# Altium schematic sheet Tracker_v1_1.SchDoc(Tracker_v1_1) (continued)

## Library data of the parts
C1 C4 C8 C13 C14 C17 C20 C23 = C0603C106M8PACTU: CAP CER 10UF 10V X5R 0603; Manufacturer: KEMET; Supplier Part Number 1: 2820985RL; Supplier 1: Farnell; Stock 1: 0; Pricing 1: 150=0.424, 500=0.311, 1000=0.26 (EUR); Manufacturer Part Number: C0603C106M8PACTU; Library Name: Tracker_v1_1_sch.SchLib; Component Kind: Standard
C2 C3 C7 C9-C11 C18 C19 C21 C22 = C0603C104J3RACTU: CAP CER 0.1UF 25V X7R 0603; Manufacturer: KEMET; Tolerance: 5%; Dielectric Material: Ceramic; Capacitance: 100nF; Width: 0.031inch; Voltage Rating (DC): 25V; Voltage Rating: 25V; Voltage: 25V; Thickness: 0.034inch; Termination: SMD/SMT; Supplier Part Number 1: 1650833RL; Supplier 1: Farnell; Stock 1: 0; REACH SVHC: No SVHC; Radiation Hardening: No; Pricing 1: 150=0.158, 500=0.132, 1000=0.12 (EUR); Packaging: Tape and Reel; Package Quantity: 4000; Number of Pins: 2; Mount: Surface Mount,PCB; Min Operating Temperature: -55°C; Max Operating Temperature: 125°C; Manufacturer Part Number: C0603C104J3RACTU; Library Name: Tracker_v1_1_sch.SchLib; Length: 1.6mm; Lead Pitch: 0.7mm; Height: 0.8mm; Dielectric: X7R; Depth: 0.8mm; Contact Plating: Tin,Matte; ComponentLink4URL: http://www.kemet.com/kemet/web/homepage/kechome.nsf/vapubfiles/KEM_C1002_X7R_SMD.pdf/$file/KEM_C1002_X7R_SMD.pdf?src-supplier=Element14; ComponentLink4Description: http://www.kemet.com/kemet/web/homepage/kechome.nsf/vapubfiles/KEM_C1002_X7R_SMD.pdf/$file/KEM_C1002_X7R_SMD.pdf?src-supplier=Element14; ComponentLink3URL: https://www.alliedelec.com/m/d/9bfe7773041ffe9d406e68bc7b377fdd.pdf?src-supplier=Allied+Electronics; ComponentLink3Description: https://www.alliedelec.com/m/d/9bfe7773041ffe9d406e68bc7b377fdd.pdf?src-supplier=Allied+Electronics; ComponentLink2URL: http://www.farnell.com/datasheets/1851736.pdf?src-supplier=Element14; ComponentLink2Description: http://www.farnell.com/datasheets/1851736.pdf?src-supplier=Element14; ComponentLink1URL: https://www.verical.com/server-webapp/html/getDatasheet?partID=33575&src-supplier=Verical; ComponentLink1Description: https://www.verical.com/server-webapp/html/getDatasheet?partID=33575&src-supplier=Verical; Component Kind: Standard; Case Code (Metric): 1608; Case Code (Imperial): 0603; Case/Package: 0603
C5 C15 = C0603C105K8RACTU: Cap Ceramic 1uF 10V X7R 10% SMD 0603 125C T/R; Manufacturer: KEMET; Dissipation Factor: 5%; Width: 0.8mm; Voltage Rating (DC): 10V; Voltage Rating: 10V; Tolerance: 10%; Thickness: 0.035inch; Termination: SMD/SMT; Supplier Part Number 1: 2522396RL; Supplier 1: Farnell; Stock 1: 0; REACH SVHC: No SVHC; Radiation Hardening: No; Pricing 1: 150=0.0567, 500=0.0425, 1000=0.0372 (EUR); Packaging: Tape and Reel; Package Quantity: 1; Mount: Surface Mount; Min Operating Temperature: -55°C; Max Operating Temperature: 125°C; Manufacturer Part Number: C0603C105K8RACTU; Library Name: Tracker_v1_1_sch.SchLib; Length: 1.6mm; Height: 0.8mm; Dielectric Material: Ceramic; Dielectric: X7R; Depth: 0.8mm; Contact Plating: Tin,Matte; ComponentLink1URL: https://www.verical.com/server-webapp/html/getDatasheet?partID=212378&src-supplier=Verical; ComponentLink1Description: https://www.verical.com/server-webapp/html/getDatasheet?partID=212378&src-supplier=Verical; Component Kind: Standard; Case Code (Metric): 1608; Case Code (Imperial): 0603; Case/Package: 0603; Capacitance: 1uF
C6 = C0603C101K5GACTU: Cap Ceramic 100pF 50V C0G 10% SMD 0603 125C Paper T/R; Manufacturer: KEMET; Dissipation Factor: 0.1%; Width: 0.031inch; Voltage Rating (DC): 50V; Voltage Rating: 50V; Tolerance: 10%; Thickness: 0.034inch; Termination: SMD/SMT; Supplier Part Number 1: 1823375; Supplier 1: Farnell; Stock 1: 0; REACH SVHC: No SVHC; Radiation Hardening: No; Packaging: Tape and Reel; Package Quantity: 1; Mount: Surface Mount,PCB; Min Operating Temperature: -55°C; Max Operating Temperature: 125°C; Manufacturer Part Number: C0603C101K5GACTU; Library Name: Tracker_v1_1_sch.SchLib; Length: 1.6mm; Lead Pitch: 0.7mm; Lead Free: Lead Free; Height: 0.8mm; Dielectric Material: Ceramic; Dielectric: C0G; Depth: 0.8mm; Contact Plating: Tin,Matte; ComponentLink4URL: http://www.mouser.com/ds/2/212/KEM_C1003_C0G_SMD-1101588.pdf?src-supplier=Mouser; ComponentLink4Description: http://www.mouser.com/ds/2/212/KEM_C1003_C0G_SMD-1101588.pdf?src-supplier=Mouser; ComponentLink3URL: http://www.farnell.com/datasheets/1828801.pdf?src-supplier=Element14; ComponentLink3Description: http://www.farnell.com/datasheets/1828801.pdf?src-supplier=Element14; ComponentLink2URL: https://www.verical.com/server-webapp/html/getDatasheet?partID=77366&src-supplier=Verical; ComponentLink2Description: https://www.verical.com/server-webapp/html/getDatasheet?partID=77366&src-supplier=Verical; ComponentLink1URL: http://www.onlinecomponents.com/productFiles/mf-KEM/kem_c1003_c0g_smd.pdf?src-supplier=Onlinecomponents.com; ComponentLink1Description: http://www.onlinecomponents.com/productFiles/mf-KEM/kem_c1003_c0g_smd.pdf?src-supplier=Onlinecomponents.com; Component Kind: Standard; Case Code (Metric): 1608; Case Code (Imperial): 0603; Case/Package: 0603; Capacitance: 100pF
C12 = C0603C475K9PACTU: CAP CER 4.7UF 6.3V X5R 0603; Manufacturer: KEMET; Tolerance: 10%; Dissipation Factor: 5%; Dielectric Material: Ceramic; Width: 0.031inch; Voltage Rating (DC): 6.3V; Voltage Rating: 6.3V; Voltage: 6.3V; Thickness: 0.035inch; Termination: SMD/SMT; Supplier Part Number 1: 1310155; Supplier 1: Farnell; Stock 1: 0; REACH SVHC: No SVHC; Radiation Hardening: No; Packaging: Tape and Reel; Package Quantity: 1; Number of Pins: 2; Mount: Surface Mount,PCB; Min Operating Temperature: -55°C; Max Operating Temperature: 85°C; Manufacturer Part Number: C0603C475K9PACTU; Library Name: Tracker_v1_1_sch.SchLib; Length: 1.6mm; Lead Pitch: 0.7mm; Lead Free: Lead Free; Height: 0.8mm; Dielectric: X5R; Depth: 0.8mm; Contact Plating: Tin,Matte; ComponentLink4URL: http://www.farnell.com/datasheets/40889.pdf?src-supplier=Element14; ComponentLink4Description: http://www.farnell.com/datasheets/40889.pdf?src-supplier=Element14; ComponentLink3URL: http://www.farnell.com/datasheets/1789732.pdf?src-supplier=Element14; ComponentLink3Description: http://www.farnell.com/datasheets/1789732.pdf?src-supplier=Element14; ComponentLink2URL: http://www.farnell.com/datasheets/1732700.pdf?src-supplier=Element14; ComponentLink2Description: http://www.farnell.com/datasheets/1732700.pdf?src-supplier=Element14; ComponentLink1URL: https://api.kemet.com/component-edge/download/datasheet/C0603C475K9PACTU.pdf?src-supplier=Digi-Key; ComponentLink1Description: https://api.kemet.com/component-edge/download/datasheet/C0603C475K9PACTU.pdf?src-supplier=Digi-Key; Component Kind: Standard; Case Code (Metric): 1608; Case Code (Imperial): 0603; Case/Package: 0603; Capacitance: 4.7uF
C16 = C0603C103J3GACTU: 0603 C 10nF Ceramic Multilayer Capacitor, 25 V, +125degC, C0G Dielectric, +/-5%; Manufacturer: KEMET; Voltage Rating (DC): 25V; Voltage: 50V; Tolerance: 5%; Temperature Coefficient: 30ppm/°C; Dissipation Factor: 0.1%; Width: 0.8mm; Voltage Rating: 25V; Thickness: 0.034inch; Termination: SMD/SMT; Supplier Part Number 1: C0603C103J3GACTU-ND; Supplier 1: Digi-Key; Stock 1: 0; REACH SVHC: No SVHC; Radiation Hardening: No; Packaging: Tape and Reel; Package Quantity: 4000; Number of Pins: 2; Mount: Surface Mount; Min Operating Temperature: -55°C; Max Operating Temperature: 125°C; Manufacturer Part Number: C0603C103J3GACTU; Library Name: Tracker_v1_1_sch.SchLib; Length: 1.6mm; Lead Free: Lead Free; Height: 0.8mm; Dielectric Material: Ceramic; Dielectric: C0G; Depth: 0.8mm; Contact Plating: Tin,Matte; ComponentLink4URL: http://www.mouser.com/ds/2/212/KEM_C1003_C0G_SMD-1101588.pdf?src-supplier=Mouser; ComponentLink4Description: http://www.mouser.com/ds/2/212/KEM_C1003_C0G_SMD-1101588.pdf?src-supplier=Mouser; ComponentLink3URL: http://www.farnell.com/datasheets/1732695.pdf?src-supplier=Element14; ComponentLink3Description: http://www.farnell.com/datasheets/1732695.pdf?src-supplier=Element14; ComponentLink2URL: http://www.farnell.com/datasheets/2315092.pdf?src-supplier=Farnell; ComponentLink2Description: http://www.farnell.com/datasheets/2315092.pdf?src-supplier=Farnell; ComponentLink1URL: https://www.verical.com/server-webapp/html/getDatasheet?partID=556319&src-supplier=Verical; ComponentLink1Description: https://www.verical.com/server-webapp/html/getDatasheet?partID=556319&src-supplier=Verical; Component Kind: Standard; Case Code (Metric): 1608; Case Code (Imperial): 0603; Case/Package: 0603; Capacitance: 10nF
CON1 = Micro USB SMD: AMPHENOL FCI - 10104110-0001LF - MICRO USB, 2.0 TYPE B, RECEPTACLE, SMT; USB Standard: USB 2.0; USB Connector Type: Micro USB Type B; SVHC: No SVHC (15-Jun-2015); No. of Positions: 5; No. of Contacts: 5Contacts<!--FANDB--><div class="features-and-benefits-container"><div class="features-and-benefits-description"><span class="features-benefits-value">The 10104110-0001LF is a micro-USB type-B Receptacle Connector, comply with physical, electrical and environmental requirements of micro-USB standard (USB 2.0). Space receptacles and plugs are available in a wide variety of mounting and termination configurations, permitting their use in various applications. Compared with the standard mini-B connectors, the size is reduced by approximately 60% while still allowing a high-speed data transfer of 480; Contact Termination Type: Surface Mount; Contact Termination: Surface Mount Right Angle; Connector Type: USB Micro B; Supplier Part Number 1: 2293753; Supplier 1: Farnell; Stock 1: 25585; RoHS: YES; Product Range: -; Pricing 1: 1=0.464, 10=0.451, 25=0.437, 50=0.424, 100=0.41, 250=0.291 (GBP); Orientation: Right Angle; Manufacturer Part Number: 10104110-0001LF; Manufacturer: AMPHENOL FCI; Library Name: Tracker_v1_1_sch.SchLib; Gender: Receptacle; Contact Plating: Gold Plated Contacts; Contact Material: Copper Alloy; Connector Mounting: Surface Mount; ComponentLink1URL: http://www.farnell.com/datasheets/1469072.pdf; ComponentLink1Description: http://www.farnell.com/datasheets/1469072.pdf; Component Kind: Standard
CON2 CON3 = 73412-0110: RF Connectors / Coaxial Connectors MCX V PCB JACK SMT 1.25MM MNT HGT; Manufacturer: Molex; Voltage Rating (AC): 60V; Polarity: Standard; Max Frequency: 6GHz; Mating Cycles: 30; Insulation Resistance: 500MR; Impedance: 50R; Gender: Female; Body Plating: Gold; Body Material: Liquid Crystal Polymer,Polymer; Voltage Rating: 60V; Termination: Solder; Supplier Part Number 1: 1340200; Supplier 1: Farnell; Stock 1: 4654; REACH SVHC: Unknown; Radiation Hardening: No; Pricing 1: 1=0.402, 150=0.368, 400=0.334, 1250=0.327, 2500=0.32 (EUR); Packaging: Tape and Reel; Package Quantity: 5000; Orientation: Straight; Mount: PCB,Surface Mount,Through Hole; Min Operating Temperature: -40°C; Max Operating Temperature: 90°C; Material: Bronze; Manufacturer Part Number: 73412-0110; Library Name: Tracker_v1_1_sch.SchLib; Lead Free: Lead Free; Halogen Free: Low Halogen; Frequency: 6GHz; Contact Plating: Gold; Contact Material: Brass,Bronze; Connector Type: Coaxial; ComponentLink7URL: http://www.molex.com/webdocs/datasheets/pdf/en-us/0734120110_RF_COAX_CONNECTORS.pdf?src-supplier=Avnet; ComponentLink7Description: http://www.molex.com/webdocs/datasheets/pdf/en-us/0734120110_RF_COAX_CONNECTORS.pdf?src-supplier=Avnet; ComponentLink6URL: http://www.farnell.com/datasheets/1808577.pdf?src-supplier=Element14; ComponentLink6Description: http://www.farnell.com/datasheets/1808577.pdf?src-supplier=Element14; ComponentLink5URL: http://www.onlinecomponents.com/productFiles/mf-MOL/1387750734120110_rf_coax_nectors.pdf?src-supplier=Onlinecomponents.com; ComponentLink5Description: http://www.onlinecomponents.com/productFiles/mf-MOL/1387750734120110_rf_coax_nectors.pdf?src-supplier=Onlinecomponents.com; ComponentLink4URL: http://www.molex.com/webdocs/datasheets/pdf/en-us/0734120110_RF_COAX_CONNECTORS.pdf?src-supplier=Mouser; ComponentLink4Description: http://www.molex.com/webdocs/datasheets/pdf/en-us/0734120110_RF_COAX_CONNECTORS.pdf?src-supplier=Mouser; ComponentLink3URL: http://www.farnell.com/datasheets/1841262.pdf?src-supplier=Farnell; ComponentLink3Description: http://www.farnell.com/datasheets/1841262.pdf?src-supplier=Farnell; ComponentLink2URL: http://uk.rs-online.com/webdocs/13c4/0900766b813c49d5.pdf?src-supplier=RSComponents; ComponentLink2Description: http://uk.rs-online.com/webdocs/13c4/0900766b813c49d5.pdf?src-supplier=RSComponents; ComponentLink1URL: http://www.molex.com/webdocs/datasheets/pdf/en-us/0734120110_RF_COAX_CONNECTORS.pdf?src-supplier=Avnet+Europe; ComponentLink1Description: http://www.molex.com/webdocs/datasheets/pdf/en-us/0734120110_RF_COAX_CONNECTORS.pdf?src-supplier=Avnet+Europe; Component Kind: Standard
D1 = MBR120VLSFT1G: DIODE SCHOTTKY 20V 1A SOD123FL; Manufacturer: ON Semiconductor; Polarity: Standard; Peak Reverse Current: 600uA; Peak Non-Repetitive Surge Current: 45A; Forward Voltage: 340mV; Element Configuration: Single; Width: 1.8mm; Voltage Rating (DC): 20V; Supplier Part Number 1: 2393584; Supplier 1: Farnell; Stock 1: 0; REACH SVHC: No SVHC; Radiation Hardening: No; Packaging: Tape and Reel; Package Quantity: 3000; Output Current: 1A; Number of Pins: 2; Min Operating Temperature: -65°C; Max Surge Current: 45A; Max Reverse Voltage (DC): 20V; Max Reverse Leakage Current: 600uA; Max Repetitive Reverse Voltage (Vrrm): 20V; Max Operating Temperature: 125°C; Max Forward Surge Current (Ifsm): 45A; Manufacturer Part Number: MBR120VLSFT1G; Library Name: Tracker_v1_1_sch.SchLib; Length: 2.9mm; Lead Free: Lead Free; Height: 0.9mm; Halogen Free: Halogen Free; Forward Current: 1A; Current Rating: 1A; Contact Plating: Tin,Matte; ComponentLink6URL: http://www.onsemi.cn/pub_link/Collateral/MBR120VLSFT1-D.PDF?src-supplier=Avnet+Asia; ComponentLink6Description: http://www.onsemi.cn/pub_link/Collateral/MBR120VLSFT1-D.PDF?src-supplier=Avnet+Asia; ComponentLink5URL: http://www.onsemi.com/pub/Collateral/MBR120VLSFT1-D.PDF?src-supplier=Digi-Key; ComponentLink5Description: http://www.onsemi.com/pub/Collateral/MBR120VLSFT1-D.PDF?src-supplier=Digi-Key; ComponentLink4URL: http://www.onsemi.com/pub/Collateral/MBR120VLSFT1-D.PDF?src-supplier=Future+Electronics; ComponentLink4Description: http://www.onsemi.com/pub/Collateral/MBR120VLSFT1-D.PDF?src-supplier=Future+Electronics; ComponentLink3URL: https://www.alliedelec.com/m/d/71572cff3445748bb7aa75769c4030bb.pdf?src-supplier=Allied+Electronics; ComponentLink3Description: https://www.alliedelec.com/m/d/71572cff3445748bb7aa75769c4030bb.pdf?src-supplier=Allied+Electronics; ComponentLink2URL: http://www.onsemi.cn/pub_link/Collateral/MBR120VLSFT1-D.PDF?src-supplier=Avnet+Europe; ComponentLink2Description: http://www.onsemi.cn/pub_link/Collateral/MBR120VLSFT1-D.PDF?src-supplier=Avnet+Europe; ComponentLink1URL: http://www.farnell.com/datasheets/85900.pdf?src-supplier=Element14; ComponentLink1Description: http://www.farnell.com/datasheets/85900.pdf?src-supplier=Element14; Component Kind: Standard; Average Rectified Current: 1A
F1 F2 = 046701.5NR: FUSE BOARD MNT 1.5A 32VAC 65VDC; Manufacturer: Littelfuse; Working Voltage: 32V; Resistance: 41mR; Power Dissipation: 121.7mW; Fuse Type: Board Mount (Cartridge Style Excluded); DC Cold Resistance: 38.5mR; Body Material: Thermoplastic; Approvals: UL,CSA; Width: 0.813mm; Voltage Rating (DC): 32V; Voltage Rating (AC): 32V; Voltage Rating: 32V; Termination: SMD/SMT; Supplier Part Number 1: 1596948RL; Supplier 1: Farnell; Stock 1: 0; REACH SVHC: Unknown; Radiation Hardening: No; Packaging: Tape and Reel; Package Quantity: 5000; Number of Pins: 2; Mount: Surface Mount; Min Operating Temperature: -55°C; Max Operating Temperature: 90°C; Manufacturer Part Number: 046701.5NR; Library Name: Tracker_v1_1_sch.SchLib; Length: 1.6mm; Lead Free: Lead Free; Height: 0.012inch; Fuse Current: 1.5A; Depth: 0.81mm; Current Rating: 1.5A; Composition: Thin Film; ComponentLink4URL: https://www.verical.com/server-webapp/html/getDatasheet?partID=772543&src-supplier=Verical; ComponentLink4Description: https://www.verical.com/server-webapp/html/getDatasheet?partID=772543&src-supplier=Verical; ComponentLink3URL: http://www.littelfuse.com/data/en/Data_Sheets/467.pdf?src-supplier=Future+Electronics; ComponentLink3Description: http://www.littelfuse.com/data/en/Data_Sheets/467.pdf?src-supplier=Future+Electronics; ComponentLink2URL: http://www.littelfuse.com/~/media/electronics/datasheets/fuses/littelfuse_fuse_467_datasheet.pdf.pdf?src-supplier=Digi-Key; ComponentLink2Description: http://www.littelfuse.com/~/media/electronics/datasheets/fuses/littelfuse_fuse_467_datasheet.pdf.pdf?src-supplier=Digi-Key; ComponentLink1URL: http://www.farnell.com/datasheets/1851810.pdf?src-supplier=Element14; ComponentLink1Description: http://www.farnell.com/datasheets/1851810.pdf?src-supplier=Element14; Component Kind: Standard; Case Code (Metric): 1608; Case Code (Imperial): 0603; Case/Package: 0603
J1 = Header 2x1: Library Name: Tracker_v1_1_sch.SchLib; Component Kind: Standard
L1 = L1210R2R2MDWIT: FIXED IND 2.2UH 2A 80 MOHM SMD; Manufacturer: KEMET; Tolerance: 20%; Test Frequency: 100kHz; Shielding: Unshielded; Self Resonant Frequency: 190MHz; DC Resistance (DCR): 80mR; DC Current: 1.13A; Core Material: Ferrite; Width: 0.098inch; Termination: SMD/SMT; Supplier Part Number 1: 399-9598-6-ND; Supplier 1: Digi-Key; Stock 1: 17546; REACH SVHC: No SVHC; Radiation Hardening: No; Pricing 1: 1=0.55, 10=0.479, 100=0.3421, 500=0.2874 (USD); Packaging: Tape and Reel; Package Quantity: 1000; Mount: Surface Mount; Min Operating Temperature: -40°C; Military Standard: Not; Max Operating Temperature: 105°C; Max DC Current: 2A; Manufacturer Part Number: L1210R2R2MDWIT; Library Name: Tracker_v1_1_sch.SchLib; Length: 0.126inch; Inductance Tolerance: 20%; Inductance: 2.2uH; Height - Seated (Max): 0.106inch; Height: 2.5mm; Depth: 2.7mm; Current Rating: 2A; Composition: Ceramic,Wirewound; ComponentLink5URL: http://www.kemet.com/Lists/ProductCatalog/Attachments/57/F3115.pdf?src-supplier=Avnet; ComponentLink5Description: http://www.kemet.com/Lists/ProductCatalog/Attachments/57/F3115.pdf?src-supplier=Avnet; ComponentLink4URL: https://api.kemet.com/component-edge/download/datasheet/L1210R2R2MDWIT.pdf?src-supplier=Digi-Key; ComponentLink4Description: https://api.kemet.com/component-edge/download/datasheet/L1210R2R2MDWIT.pdf?src-supplier=Digi-Key; ComponentLink3URL: http://www.farnell.com/datasheets/27262.pdf?src-supplier=Element14; ComponentLink3Description: http://www.farnell.com/datasheets/27262.pdf?src-supplier=Element14; ComponentLink2URL: https://content.kemet.com/datasheets/F3115.pdf?src-supplier=Avnet+Europe; ComponentLink2Description: https://content.kemet.com/datasheets/F3115.pdf?src-supplier=Avnet+Europe; ComponentLink1URL: https://content.kemet.com/datasheets/F3115.pdf?src-supplier=Mouser; ComponentLink1Description: https://content.kemet.com/datasheets/F3115.pdf?src-supplier=Mouser; Component Kind: Standard; Case Code (Metric): 3225; Case Code (Imperial): 1210; Case/Package: 1210
L2 = LQG18HN27NJ00D: Inductor RF Chip Multi-Layer 27nH 5% 100MHz 12Q-Factor Air 300mA 550mOhm DCR 0603 T/R; Manufacturer: Murata; Shielding: Unshielded; Self Resonant Frequency: 2GHz; Q Factor: 12; DC Resistance (DCR): 550mR; Width: 0.8mm; Tolerance: 5%; Test Frequency: 100MHz; Termination: SMD/SMT; Supplier Part Number 1: 490-6579-2-ND; Supplier 1: Digi-Key; Stock 1: 0; REACH SVHC: No SVHC; Radiation Hardening: No; Packaging: Tape and Reel; Package Quantity: 4000; Mount: Surface Mount; Min Operating Temperature: -55°C; Military Standard: Not; Max Operating Temperature: 85°C; Max DC Current: 300mA; Manufacturer Part Number: LQG18HN27NJ00D; Library Name: Tracker_v1_1_sch.SchLib; Length: 0.063inch; Lead Free: Lead Free; Inductance Tolerance: 5%; Inductance: 27nH; Height - Seated (Max): 0.037inch; Height: 0.8mm; Depth: 0.95mm; DC Current: 300mA; Current Rating: 300mA; Core Material: Air; ComponentLink6URL: http://uk.rs-online.com/webdocs/0f10/0900766b80f1087c.pdf?src-supplier=RSComponents; ComponentLink6Description: http://uk.rs-online.com/webdocs/0f10/0900766b80f1087c.pdf?src-supplier=RSComponents; ComponentLink5URL: http://search.murata.co.jp/Ceramy/image/img/PDF/ENG/L0075S0083LQG18H.pdf?src-supplier=Avnet+Europe; ComponentLink5Description: http://search.murata.co.jp/Ceramy/image/img/PDF/ENG/L0075S0083LQG18H.pdf?src-supplier=Avnet+Europe; ComponentLink4URL: http://search.murata.co.jp/Ceramy/image/img/PDF/ENG/L0075S0083LQG18H.pdf?src-supplier=Avnet; ComponentLink4Description: http://search.murata.co.jp/Ceramy/image/img/PDF/ENG/L0075S0083LQG18H.pdf?src-supplier=Avnet; ComponentLink3URL: http://psearch.en.murata.com/inductor/product/LQG18HN27NJ00_.pdf?src-supplier=Digi-Key; ComponentLink3Description: http://psearch.en.murata.com/inductor/product/LQG18HN27NJ00_.pdf?src-supplier=Digi-Key; ComponentLink2URL: http://www.farnell.com/datasheets/5102.pdf?src-supplier=Element14; ComponentLink2Description: http://www.farnell.com/datasheets/5102.pdf?src-supplier=Element14; ComponentLink1URL: http://search.murata.co.jp/Ceramy/image/img/P02/JELF243B-0002.pdf?src-supplier=Digi-Key; ComponentLink1Description: http://search.murata.co.jp/Ceramy/image/img/P02/JELF243B-0002.pdf?src-supplier=Digi-Key; Component Kind: Standard; Case Code (Metric): 1608; Case Code (Imperial): 0603; Case/Package: 0603
LED1-LED3 = KP-1608CGCK: KINGBRIGHT - KP-1608CGCK - LED, 0603, 50MCD, GREEN; Wavelength: 570nm; Viewing Angle: 120°; Number of LEDs: 1; Lens Style: Rectangular,Diffused,Colorless; Lens Color: Clear; Forward Voltage: 2.1V; Forward Current: 20mA; Width: 1.6mm; Supplier Part Number 1: 2290328; Supplier 1: Farnell; Stock 1: 18535; REACH SVHC: No SVHC; Radiation Hardening: No; Pricing 1: 5=0.157, 50=0.143, 100=0.0934, 500=0.0789, 1000=0.0621 (EUR); Packaging: Tape and Reel; Number of Pins: 2; Mount: Surface Mount; Manufacturer Part Number: KP-1608CGCK; Manufacturer: Kingbright; Luminous Intensity: 50mcd; Library Name: Tracker_v1_1_sch.SchLib; Length: 0.8mm; Height: 1.1mm; Dominant Wavelength: 570nm; ComponentLink2URL: http://www.rapidonline.com/pdf/72-8670.pdf?src-supplier=Rapid+Electronics; ComponentLink2Description: http://www.rapidonline.com/pdf/72-8670.pdf?src-supplier=Rapid+Electronics; ComponentLink1URL: http://www.farnell.com/datasheets/2045956.pdf?src-supplier=Element14; ComponentLink1Description: http://www.farnell.com/datasheets/2045956.pdf?src-supplier=Element14; Component Kind: Standard; Color: Green; Case/Package: 1608
MH1-MH4 = MH_7.4MM_NC: Library Name: Tracker_v1_1_sch.SchLib; Component Kind: Standard
R1 R4 R9 = CR0603-JW-103EAS: RES 10K OHM 5% 0.01W 0603; Manufacturer: Bourns; Supplier Part Number 1: 2752989RL; Supplier 1: Farnell; Stock 1: 0; Pricing 1: 150=0.0181, 500=0.0155, 1000=0.007 (EUR); Manufacturer Part Number: CR0603-JW-103EAS; Library Name: Tracker_v1_1_sch.SchLib; Component Kind: Standard
R2 R3 = CRCW060327R0FKEA: RES SMD 27 OHM 1% 1/10W 0603; Manufacturer: Vishay; Voltage Rating (DC): 75V; Temperature Coefficient: 100ppm/°C; Power Rating: 100mW; Width: 0.85mm; Weight: 7.1E-05oz; Voltage Rating: 75V; Tolerance: 1%; Termination: SMD/SMT; Supplier Part Number 1: 1151876; Supplier 1: Farnell; Stock 1: 0; Resistance: 27R; REACH SVHC: Unknown; Radiation Hardening: No; Packaging: Tape and Reel; Package Quantity: 5000; Number of Pins: 2; Mount: Surface Mount; Min Operating Temperature: -55°C; Military Standard: Not; Max Operating Temperature: 155°C; Manufacturer Part Number: CRCW060327R0FKEA; Library Name: Tracker_v1_1_sch.SchLib; Length: 1.55mm; Lead Free: Lead Free; Height: 0.45mm; Depth: 0.85mm; Contact Plating: Tin; Composition: Thick Film; ComponentLink8URL: http://www.vishay.com/docs/20035/dcrcwe3.pdf?src-supplier=Future+Electronics; ComponentLink8Description: http://www.vishay.com/docs/20035/dcrcwe3.pdf?src-supplier=Future+Electronics; ComponentLink7URL: http://www.alliedelec.com/m/d/0c934d6939ccefa68dbe0b96d821c8cf.pdf?src-supplier=Allied+Electronics; ComponentLink7Description: http://www.alliedelec.com/m/d/0c934d6939ccefa68dbe0b96d821c8cf.pdf?src-supplier=Allied+Electronics; ComponentLink6URL: http://www.vishay.com/docs/20035/dcrcwe3.pdf?src-supplier=Avnet+Europe; ComponentLink6Description: http://www.vishay.com/docs/20035/dcrcwe3.pdf?src-supplier=Avnet+Europe; ComponentLink5URL: http://uk.rs-online.com/webdocs/0d98/0900766b80d98afc.pdf?src-supplier=RSComponents; ComponentLink5Description: http://uk.rs-online.com/webdocs/0d98/0900766b80d98afc.pdf?src-supplier=RSComponents; ComponentLink4URL: http://www.farnell.com/datasheets/2111203.pdf?src-supplier=Element14; ComponentLink4Description: http://www.farnell.com/datasheets/2111203.pdf?src-supplier=Element14; ComponentLink3URL: http://www.farnell.com/datasheets/1697337.pdf?src-supplier=Element14; ComponentLink3Description: http://www.farnell.com/datasheets/1697337.pdf?src-supplier=Element14; ComponentLink2URL: http://www.farnell.com/datasheets/2046716.pdf?src-supplier=Element14; ComponentLink2Description: http://www.farnell.com/datasheets/2046716.pdf?src-supplier=Element14; ComponentLink1URL: http://www.mouser.com/ds/2/427/dcrcwe3-109170.pdf?src-supplier=Mouser; ComponentLink1Description: http://www.mouser.com/ds/2/427/dcrcwe3-109170.pdf?src-supplier=Mouser; Component Kind: Standard; Case Code (Metric): 1608; Case Code (Imperial): 0603; Case/Package: 0603
R5 R6 = CR0603-JW-472ELF: Res Thick Film 0603 4.7K Ohm 5% 1/10W ±200ppm/°C Molded SMD SMD Paper Tape on Plastic Reel; Manufacturer: Bourns; Voltage Rating (DC): 50V; Resistance: 4.7kR; Power Rating: 100mW; Width: 0.8mm; Voltage Rating: 50V; Tolerance: 5%; Thickness: 457um; Termination: SMD/SMT; Temperature Coefficient: 200ppm/°C; Supplier Part Number 1: 2371774; Supplier 1: Farnell; Stock 1: 0; REACH SVHC: No SVHC; Radiation Hardening: No; Packaging: Tape and Reel; Package Quantity: 1; Number of Terminations: 2; Number of Pins: 2; Mount: Surface Mount; Min Operating Temperature: -55°C; Max Power Dissipation: 100mW; Max Operating Temperature: 155°C; Manufacturer Part Number: CR0603-JW-472ELF; Library Name: Tracker_v1_1_sch.SchLib; Length: 1.6mm; Height: 0.45mm; Features: Moisture Resistant; Depth: 0.8mm; Contact Plating: Tin,Lead; Composition: Thick Film; ComponentLink6URL: http://www.produktinfo.conrad.com/datenblaetter/1000000-1099999/001055503-da-01-en-SMD_WID_CR0603_JW_472ELF_4_7K_OHM_1_10W.pdf?src-supplier=Conrad; ComponentLink6Description: http://www.produktinfo.conrad.com/datenblaetter/1000000-1099999/001055503-da-01-en-SMD_WID_CR0603_JW_472ELF_4_7K_OHM_1_10W.pdf?src-supplier=Conrad; ComponentLink5URL: http://uk.rs-online.com/webdocs/0fcd/0900766b80fcdb72.pdf?src-supplier=RSComponents; ComponentLink5Description: http://uk.rs-online.com/webdocs/0fcd/0900766b80fcdb72.pdf?src-supplier=RSComponents; ComponentLink4URL: http://www.farnell.com/datasheets/1856384.pdf?src-supplier=Element14; ComponentLink4Description: http://www.farnell.com/datasheets/1856384.pdf?src-supplier=Element14; ComponentLink3URL: https://www.alliedelec.com/m/d/8318635a9e5af6f7dacb272be3e73d2e.pdf?src-supplier=Allied+Electronics; ComponentLink3Description: https://www.alliedelec.com/m/d/8318635a9e5af6f7dacb272be3e73d2e.pdf?src-supplier=Allied+Electronics; ComponentLink2URL: http://www.bourns.com/pdfs/chpreztr.pdf?src-supplier=Avnet; ComponentLink2Description: http://www.bourns.com/pdfs/chpreztr.pdf?src-supplier=Avnet; ComponentLink1URL: http://www.farnell.com/datasheets/2178762.pdf?src-supplier=Newark; ComponentLink1Description: http://www.farnell.com/datasheets/2178762.pdf?src-supplier=Newark; Component Kind: Standard; Case Code (Metric): 1608; Case Code (Imperial): 0603; Case/Package: 0603
R7 R10 R11 = CR0603-JW-511ELF: Res Thick Film 0603 510 Ohm 5% 1/10W ±200ppm/°C Molded SMD SMD Paper Tape on Plastic Reel; Manufacturer: Bourns; Voltage Rating: 50V; Power Rating: 100mW; Width: 0.8mm; Tolerance: 5%; Thickness: 457um; Termination: SMD/SMT; Temperature Coefficient: 200ppm/°C; Supplier Part Number 1: 2908378; Supplier 1: Farnell; Stock 1: 79590; Resistance: 510R; Radiation Hardening: No; Pricing 1: 10=0.016, 100=0.0113, 500=0.0089, 1000=0.0077 (EUR); Packaging: Tape and Reel; Package Quantity: 1; Number of Terminations: 2; Mount: Surface Mount; Min Operating Temperature: -55°C; Military Standard: Not; Max Power Dissipation: 100mW; Max Operating Temperature: 155°C; Manufacturer Part Number: CR0603-JW-511ELF; Library Name: Tracker_v1_1_sch.SchLib; Length: 1.6mm; Height: 0.022inch; Features: Moisture Resistant; Depth: 0.8mm; Contact Plating: Tin; Composition: Thick Film; ComponentLink3URL: https://www.bourns.com/docs/Product-Datasheets/CHPREZTR.pdf?src-supplier=Digi-Key; ComponentLink3Description: https://www.bourns.com/docs/Product-Datasheets/CHPREZTR.pdf?src-supplier=Digi-Key; ComponentLink2URL: http://www.mouser.com/ds/2/54/chpreztr-977002.pdf?src-supplier=Mouser; ComponentLink2Description: http://www.mouser.com/ds/2/54/chpreztr-977002.pdf?src-supplier=Mouser; ComponentLink1URL: http://www.bourns.com/pdfs/chpreztr.pdf?src-supplier=Avnet; ComponentLink1Description: http://www.bourns.com/pdfs/chpreztr.pdf?src-supplier=Avnet; Component Kind: Standard; Case Code (Metric): 1608; Case Code (Imperial): 0603; Case/Package: 0603
R8 R12 R14 R15 R17-R20 R22 = RMCF0603ZT0R00: RES 0 OHM JUMPER 1/10W 0603; Manufacturer: Stackpole Electronics; Working Voltage: 75V; Resistance: 0mR; Power Rating: 100mW; Current Rating: 1A; Width: 0.031inch; Supplier Part Number 1: RMCF0603ZT0R00-ND; Supplier 1: Digi-Key; Stock 1: 0; Radiation Hardening: No; Packaging: Tape and Reel; Number of Terminations: 2; Mount: Surface Mount; Min Operating Temperature: -55°C; Military Standard: Not; Max Power Dissipation: 100mW; Max Operating Temperature: 155°C; Manufacturer Part Number: RMCF0603ZT0R00; Library Name: Tracker_v1_1_sch.SchLib; Length: 1.55mm; Lead Free: Lead Free; Height: 0.022inch; Features: Automotive AEC-Q200; Depth: 0.8mm; Contact Plating: Tin,Matte; Composition: Thick Film; Component Kind: Standard; Case Code (Metric): 1608; Case Code (Imperial): 0603; Case/Package: 0603
R13 R21 = CPF-A-0603B100KE1: TE CONNECTIVITY         CPF-A-0603B100KE1             SMD Chip Resistor, Thin Film, 100 kohm, 50 V, 0603 [1608 Metric], 62.5 mW,  0.1%, CPF-A Series; Voltage Rating (DC): 50V; Temperature Coefficient: 25ppm/°C; Resistance: 100kR; Power Rating: 63mW; Number of Resistors: 1; Voltage Rating: 50V; Tolerance: 0.1%; Termination: Solder; Supplier Part Number 1: 2483881RL; Supplier 1: Farnell; Stock 1: 0; REACH SVHC: No SVHC; Pricing 1: 150=0.209, 250=0.198, 500=0.172, 1000=0.135, 5000=0.13 (EUR); Packaging: Tape and Reel; Number of Terminations: 2; Mount: Surface Mount; Manufacturer Part Number: CPF-A-0603B100KE1; Manufacturer: TE Connectivity; Library Name: Tracker_v1_1_sch.SchLib; ELV: Compliant; Composition: Thin Film; Component Kind: Standard; Case Code (Metric): 1608; Case Code (Imperial): 0603; Case/Package: 0603
R16 = CRCW060310R0FKEA: RES SMD 10 OHM 1% 1/10W 0603; Manufacturer: Vishay; Voltage Rating (DC): 75V; Temperature Coefficient: 100ppm/°C; Power Rating: 100mW; Width: 0.033inch; Weight: 7.1E-05oz; Voltage Rating: 75V; Tolerance: 1%; Termination: SMD/SMT; Supplier Part Number 1: 541-10.0HTR-ND; Supplier 1: Digi-Key; Stock 1: 0; Resistance: 10R; REACH SVHC: Unknown; Packaging: Tape and Reel; Package Quantity: 10; Number of Terminations: 2; Number of Pins: 2; Mount: Surface Mount; Min Operating Temperature: -55°C; Military Standard: Not; Max Power Dissipation: 100mW; Max Operating Temperature: 155°C; Manufacturer Part Number: CRCW060310R0FKEA; Library Name: Tracker_v1_1_sch.SchLib; Length: 1.55mm; Lead Free: Lead Free; Height: 0.45mm; Features: Automotive AEC-Q200; Depth: 0.85mm; Contact Plating: Tin; Composition: Thick Film; ComponentLink8URL: http://www.farnell.com/datasheets/2045673.pdf?src-supplier=Element14; ComponentLink8Description: http://www.farnell.com/datasheets/2045673.pdf?src-supplier=Element14; ComponentLink7URL: http://www.mouser.com/ds/2/427/dcrcwe3-109170.pdf?src-supplier=Mouser; ComponentLink7Description: http://www.mouser.com/ds/2/427/dcrcwe3-109170.pdf?src-supplier=Mouser; ComponentLink6URL: http://www.vishay.com/docs/20035/dcrcwe3.pdf?src-supplier=Avnet; ComponentLink6Description: http://www.vishay.com/docs/20035/dcrcwe3.pdf?src-supplier=Avnet; ComponentLink5URL: http://www.alliedelec.com/m/d/0c934d6939ccefa68dbe0b96d821c8cf.pdf?src-supplier=Allied+Electronics; ComponentLink5Description: http://www.alliedelec.com/m/d/0c934d6939ccefa68dbe0b96d821c8cf.pdf?src-supplier=Allied+Electronics; ComponentLink4URL: https://www.distrelec.biz/Web/Downloads/a_/en/flD-CRCW-e3_data_en.pdf?mime=application%2Fpdf&src-supplier=Distrelec; ComponentLink4Description: https://www.distrelec.biz/Web/Downloads/a_/en/flD-CRCW-e3_data_en.pdf?mime=application%2Fpdf&src-supplier=Distrelec; ComponentLink3URL: http://www.vishay.com/docs/20035/dcrcwe3.pdf?src-supplier=Future+Electronics; ComponentLink3Description: http://www.vishay.com/docs/20035/dcrcwe3.pdf?src-supplier=Future+Electronics; ComponentLink2URL: http://uk.rs-online.com/webdocs/0d98/0900766b80d98afc.pdf?src-supplier=RSComponents; ComponentLink2Description: http://uk.rs-online.com/webdocs/0d98/0900766b80d98afc.pdf?src-supplier=RSComponents; ComponentLink1URL: http://www.vishay.com/docs/20035/dcrcwe3.pdf?src-supplier=Digi-Key; ComponentLink1Description: http://www.vishay.com/docs/20035/dcrcwe3.pdf?src-supplier=Digi-Key; Component Kind: Standard; Case Code (Metric): 1608; Case Code (Imperial): 0603; Case/Package: 0603
SW1 = KMR211GLFS: SWITCH TACTILE SPST-NO 0.05A 32V; Manufacturer: ITT C&K; Throw Configuration: SPST; Switch Travel: 0.2mm; Switch Function: Off-Mom; Power Rating: 1W; Operating Force: 1.2N; Mechanical Life: 200000Cycles; Max Current Rating: 50mA; Illumination Type: Non-Illuminated,Illuminated; Actuator Type: Standard; Actuator Length: 0.5mm; Width: 2.8mm; Voltage Rating (DC): 32V; Termination: Gull Wing; Supplier Part Number 1: 1437635RL; Supplier 1: Farnell; Stock 1: 0; REACH SVHC: No SVHC; Radiation Hardening: No; Packaging: Tape and Reel; Package Quantity: 1; Orientation: Straight; Mount: Surface Mount; Min Operating Temperature: -40°C; Max Voltage Rating (DC): 32V; Max Operating Temperature: 85°C; Manufacturer Part Number: KMR211GLFS; Library Name: Tracker_v1_1_sch.SchLib; Length: 2.8mm; Lead Free: Lead Free; Depth: 4.6mm; Current Rating: 50mA; Contact Plating: Silver; Contact Material: Silver; Contact Current Rating: 50mA; ComponentLink7URL: http://www.ckswitches.com/media/1479/kmr2.pdf?src-supplier=Onlinecomponents.com; ComponentLink7Description: http://www.ckswitches.com/media/1479/kmr2.pdf?src-supplier=Onlinecomponents.com; ComponentLink6URL: https://www.ckswitches.com/media/1479/kmr2.pdf?src-supplier=Digi-Key; ComponentLink6Description: https://www.ckswitches.com/media/1479/kmr2.pdf?src-supplier=Digi-Key; ComponentLink5URL: http://www.ck-components.com/14414/kmr2_9aug12.pdf/?src-supplier=Avnet+Europe; ComponentLink5Description: http://www.ck-components.com/14414/kmr2_9aug12.pdf/?src-supplier=Avnet+Europe; ComponentLink4URL: http://www.ck-components.com/14414/kmr2_9aug12.pdf/?src-supplier=Avnet; ComponentLink4Description: http://www.ck-components.com/14414/kmr2_9aug12.pdf/?src-supplier=Avnet; ComponentLink3URL: http://www.farnell.com/datasheets/2044619.pdf?src-supplier=Element14; ComponentLink3Description: http://www.farnell.com/datasheets/2044619.pdf?src-supplier=Element14; ComponentLink2URL: http://www1.futureelectronics.com/doc/C%20-%20K%20COMPONENTS/KMR211GLFS.pdf?src-supplier=Future+Electronics; ComponentLink2Description: http://www1.futureelectronics.com/doc/C%20-%20K%20COMPONENTS/KMR211GLFS.pdf?src-supplier=Future+Electronics; ComponentLink1URL: http://uk.rs-online.com/webdocs/129c/0900766b8129c9f0.pdf?src-supplier=RSComponents; ComponentLink1Description: http://uk.rs-online.com/webdocs/129c/0900766b8129c9f0.pdf?src-supplier=RSComponents; Component Kind: Standard
TP1-TP8 = TestPoint: TEST POINT ROUND SMD; FIT: 0.94; Library Name: Passive.IntLib; Komp / Device: SMD; ComponentLink1Description: Datasheet; Component Kind: Standard
U1 = CMWX1ZZABZ-091: MURATA - CMWX1ZZABZ-091 - RF MODULE, 860-930MHZ, 4.8KBPS, 18.5DBM; Supplier Part Number 1: 2802546; Supplier 1: Farnell; Stock 1: 1534; Pricing 1: 1=16.46, 5=16.26, 10=13.54, 50=12.97, 100=12.39, 250=9.81 (EUR); Manufacturer Part Number: CMWX1ZZABZ-091; Manufacturer: Murata; Library Name: Tracker_v1_1_sch.SchLib; Component Kind: Standard
U2 = MCP73831T-2ACI/OT: MICROCHIP - MCP73831T-2ACI/OT - LI-ION/LI-POLY CHARGE CONTROLLER; Voltage Rating: 4.534V; Output Voltage: 4.2V; Output Current: 500mA; Number of Outputs: 1; Min Supply Voltage: 3.75V; Min Output Voltage: 4.168V; Max Supply Voltage: 6V; Max Power Dissipation: 1.54W; Max Output Voltage: 4.5V; Drain to Source Resistance: 350mR; Width: 0.071inch; Weight: 0.00709oz; Termination: SMD/SMT; Supplier Part Number 1: 1332158; Supplier 1: Farnell; Stock 1: 14250; REACH SVHC: No SVHC; Radiation Hardening: No; Pricing 1: 1=0.493, 10=0.484, 25=0.412, 50=0.39, 100=0.368 (EUR); Packaging: Tape and Reel; Number of Pins: 5; Number of Channels: 1; Number of Cells: 1; Mount: Surface Mount; Min Operating Temperature: -40°C; Max Operating Temperature: 85°C; Max Input Voltage: 6V; Max Charge Current: 500mA; Manufacturer Part Number: MCP73831T-2ACI/OT; Manufacturer: Microchip; Library Name: Tracker_v1_1_sch.SchLib; Length: 0.122inch; Lead Free: Lead Free; Height: 0.051inch; Contact Plating: Tin,Matte; ComponentLink7URL: http://www.produktinfo.conrad.com/datenblaetter/650000-674999/651521-da-01-en-CONTR__MCP73831T_2ACI_OT_SOT_23_5_MCP.pdf?src-supplier=Conrad; ComponentLink7Description: http://www.produktinfo.conrad.com/datenblaetter/650000-674999/651521-da-01-en-CONTR__MCP73831T_2ACI_OT_SOT_23_5_MCP.pdf?src-supplier=Conrad; ComponentLink6URL: http://www.microchip.com/mymicrochip/filehandler.aspx?ddocname=en025112&src-supplier=Future+Electronics; ComponentLink6Description: http://www.microchip.com/mymicrochip/filehandler.aspx?ddocname=en025112&src-supplier=Future+Electronics; ComponentLink5URL: http://www.farnell.com/datasheets/2124927.pdf?src-supplier=Newark; ComponentLink5Description: http://www.farnell.com/datasheets/2124927.pdf?src-supplier=Newark; ComponentLink4URL: http://ww1.microchip.com/downloads/en/DeviceDoc/21984e.pdf?src-supplier=Avnet; ComponentLink4Description: http://ww1.microchip.com/downloads/en/DeviceDoc/21984e.pdf?src-supplier=Avnet; ComponentLink3URL: http://www.farnell.com/datasheets/2124927.pdf?src-supplier=Element14; ComponentLink3Description: http://www.farnell.com/datasheets/2124927.pdf?src-supplier=Element14; ComponentLink2URL: http://www.farnell.com/datasheets/630369.pdf?src-supplier=Element14; ComponentLink2Description: http://www.farnell.com/datasheets/630369.pdf?src-supplier=Element14; ComponentLink1URL: http://www.microchip.com/mymicrochip/filehandler.aspx?ddocname=en023833&src-supplier=Digi-Key; ComponentLink1Description: http://www.microchip.com/mymicrochip/filehandler.aspx?ddocname=en023833&src-supplier=Digi-Key; Component Kind: Standard; Case/Package: SOT-23
U3 = DMG3415U-7: MOSFET P-CH 20V 4A SOT-23; Manufacturer: Diodes; Turn-On Delay Time: 71ns; Turn-Off Delay Time: 795ns; Threshold Voltage: -550mV; Rise Time: 117ns; Rds On Max: 39mR; Power Dissipation: 900mW; On-State Resistance: 42.5mR; Number of Elements: 1; Input Capacitance: 294pF; Gate to Source Voltage (Vgs): 8V; Fall Time: 393ns; Element Configuration: Single; Drain to Source Breakdown Voltage: -20V; Width: 1.3mm; Weight: 0.000282oz; Supplier Part Number 1: 1843688RL; Supplier 1: Farnell; Stock 1: 0; REACH SVHC: No SVHC; Radiation Hardening: No; Packaging: Tape and Reel; Package Quantity: 3000; Number of Pins: 3; Number of Channels: 1; Nominal Vgs: -550mV; Mount: Surface Mount; Min Operating Temperature: -55°C; Max Power Dissipation: 900mW; Max Operating Temperature: 150°C; Manufacturer Part Number: DMG3415U-7; Library Name: Tracker_v1_1_sch.SchLib; Length: 2.9mm; Lead Free: Lead Free; Height: 1mm; Drain to Source Voltage (Vdss): 20V; Drain to Source Resistance: 42.5mR; Continuous Drain Current (ID): 4A; Contact Plating: Tin,Matte; ComponentLink5URL: http://www.diodes.com/_files/datasheets/ds31735.pdf?src-supplier=Future+Electronics; ComponentLink5Description: http://www.diodes.com/_files/datasheets/ds31735.pdf?src-supplier=Future+Electronics; ComponentLink4URL: http://www.farnell.com/datasheets/1915618.pdf?src-supplier=Element14; ComponentLink4Description: http://www.farnell.com/datasheets/1915618.pdf?src-supplier=Element14; ComponentLink3URL: http://www.mouser.com/ds/2/115/ds31735-64958.pdf?src-supplier=Mouser; ComponentLink3Description: http://www.mouser.com/ds/2/115/ds31735-64958.pdf?src-supplier=Mouser; ComponentLink2URL: https://www.diodes.com/assets/Datasheets/ds31735.pdf?src-supplier=Digi-Key; ComponentLink2Description: https://www.diodes.com/assets/Datasheets/ds31735.pdf?src-supplier=Digi-Key; ComponentLink1URL: http://www.diodes.com/datasheets/ds31735.pdf?src-supplier=Avnet; ComponentLink1Description: http://www.diodes.com/datasheets/ds31735.pdf?src-supplier=Avnet; Component Kind: Standard; Case/Package: SOT-23
U4 = TPS62740DSSR: Switching Voltage Regulators 360-nA Iq SD Cnvtr w/ Intg Load Switch; Manufacturer: Texas Instruments; Switching Frequency: 2MHz; Quiescent Current: 300nA; Output Voltage: 3.3V; Output Current: 300mA; Operating Supply Voltage: 5.5V; Number of Outputs: 1; Min Supply Voltage: 2.2V; Min Output Voltage: 1.8V; Efficiency: 90%; Supplier Part Number 1: 2542791; Supplier 1: Farnell; Stock 1: 0; REACH SVHC: No SVHC; Radiation Hardening: No; Pricing 1: 3000=0.685, 6000=0.679, 9000=0.672 (EUR); Packaging: Tape and Reel; Package Quantity: 3000; Operating Supply Current: 300mA; Number of Pins: 12; Mount: Surface Mount; Min Operating Temperature: -40°C; Min Input Voltage: 2.2V; Max Output Voltage: 3.3V; Max Output Current: 300mA; Max Operating Temperature: 85°C; Max Input Voltage: 5.5V; Manufacturer Part Number: TPS62740DSSR; Library Name: Tracker_v1_1_sch.SchLib; Lead Free: Lead Free; ComponentLink2URL: http://www.ti.com/lit/ds/symlink/tps62740.pdf?src-supplier=Avnet; ComponentLink2Description: http://www.ti.com/lit/ds/symlink/tps62740.pdf?src-supplier=Avnet; ComponentLink1URL: http://www.ti.com/lit/ds/symlink/tps62740.pdf?src-supplier=Digi-Key; ComponentLink1Description: http://www.ti.com/lit/ds/symlink/tps62740.pdf?src-supplier=Digi-Key; Component Kind: Standard
U5 = MAX-M8Q-0: Rf Rcvr Gnss/gps 1.575GHZ 18LCC; Manufacturer: u-blox; Supplier Part Number 1: 672-1003-6-ND; Supplier 1: Digi-Key; Stock 1: 10805; Manufacturer Part Number: MAX-M8Q-0; Library Name: Tracker_v1_1_sch.SchLib; Component Kind: Standard
U6 = TSL25911FN: IC LIGHT TO DGTL CONV 6-DFN; Manufacturer: ams TAOS; Wavelength: 850nm; Output Type: I2C; Operating Supply Voltage: 3V; Max Frequency: 400kHz; Data Bus Width: 16b; Supplier Part Number 1: TSL25911FNTR-ND; Supplier 1: Digi-Key; Stock 1: 28000; Packaging: Tape and Reel; Package Quantity: 1; Number of Pins: 6; Min Operating Temperature: -30°C; Max Operating Temperature: 70°C; Manufacturer Part Number: TSL25911FN; Library Name: Tracker_v1_1_sch.SchLib; Lead Free: Lead Free; Interface: I2C; ComponentLink4URL: http://www.ams.com/eng/content/download/389383/1251117/221235?src-supplier=Digi-Key; ComponentLink4Description: http://www.ams.com/eng/content/download/389383/1251117/221235?src-supplier=Digi-Key; ComponentLink3URL: https://ams.com/documents/20143/36005/TSL2591_DS000338_6-00.pdf?src-supplier=Digi-Key; ComponentLink3Description: https://ams.com/documents/20143/36005/TSL2591_DS000338_6-00.pdf?src-supplier=Digi-Key; ComponentLink2URL: http://www1.futureelectronics.com/doc/AMS/TSL25911FN.pdf?src-supplier=Future+Electronics; ComponentLink2Description: http://www1.futureelectronics.com/doc/AMS/TSL25911FN.pdf?src-supplier=Future+Electronics; ComponentLink1URL: http://www.mouser.com/ds/2/588/TSL2591_DS000338_3-00-253098.pdf?src-supplier=Mouser; ComponentLink1Description: http://www.mouser.com/ds/2/588/TSL2591_DS000338_3-00-253098.pdf?src-supplier=Mouser; Component Kind: Standard; Case/Package: WFDFN
U7 = LIS2DH12TR: STMICROELECTRONICS - LIS2DH12TR - 3-AXIS ACCELEROMETER, DIGITAL, LGA-12; Output Type: SPI,I2C,Digital; Min Supply Voltage: 1.71V; Max Supply Voltage: 3.6V; Interface: I2C,SPI; Bandwidth: 672Hz; Axis: X, Y, Z; Width: 2.15mm; Supplier Part Number 1: 2433081RL; Supplier 1: Farnell; Stock 1: 0; REACH SVHC: No SVHC; Radiation Hardening: No; Packaging: Cut Tape; Number of Pins: 12; Mount: Surface Mount; Min Operating Temperature: -40°C; Max Operating Temperature: 85°C; Manufacturer Part Number: LIS2DH12TR; Manufacturer: STMicroelectronics; Library Name: Tracker_v1_1_sch.SchLib; Length: 2.15mm; Lead Free: Lead Free; Height: 1mm; Contact Plating: Gold; ComponentLink1URL: http://www.farnell.com/datasheets/2006544.pdf?src-supplier=Element14; ComponentLink1Description: http://www.farnell.com/datasheets/2006544.pdf?src-supplier=Element14; Component Kind: Standard; Case/Package: LGA
U8 = BME280: Pressure, Temperature and Humidity Sensor Digital Output 1.8V 8-Pin LGA T/R; Manufacturer: Bosch; Supplier Part Number 1: 828-1063-2-ND; Supplier 1: Digi-Key; Stock 1: 0; Number of Pins: 8; Manufacturer Part Number: BME280; Library Name: Tracker_v1_1_sch.SchLib; Lead Free: Lead Free; Component Kind: Standard; Case/Package: LGA
U9 = USBLC6-2SC6: TVS DIODE 5.25V 17V SOT23-6; Manufacturer: STMicroelectronics; Working Voltage: 5V; Test Current: 1mA; Reverse Breakdown Voltage: 6V; Power Line Protection: Yes; Polarity: Unidirectional,Bidirectional; Peak Pulse Current: 5A; Operating Supply Voltage: 1.1V; Number of Elements: 1; Number of Channels: 2; Max Reverse Leakage Current: 150nA; Element Configuration: Dual; Data Rate: 480Mbps; Capacitance: 3.5pF; Width: 1.75mm; Termination: SMD/SMT; Supplier 1: Farnell; Stock 1: 0; Reverse Standoff Voltage: 5.25V; REACH SVHC: No SVHC; Radiation Hardening: No; Packaging: Tape and Reel; Package Quantity: 3000; Number of Pins: 6; Number of Bidirectional Channels: 2; Mount: Surface Mount; Min Operating Temperature: -40°C; Min Breakdown Voltage: 6V; Max Operating Temperature: 125°C; Max Breakdown Voltage: 6V; Manufacturer Part Number: USBLC6-2SC6; Library Name: Tracker_v1_1_sch.SchLib; Length: 3.05mm; Leakage Current: 150nA; Lead Free: Lead Free; Height: 1.3mm; ESD Protection: Yes; Direction: Unidirectional,Bidirectional; Depth: 1.75mm; Contact Plating: Tin,Matte; ComponentLink6URL: http://www.produktinfo.conrad.com/datenblaetter/1100000-1199999/001184109-da-01-en-IC_ESD_PROTECTI_USBLC6_2SC6_SOT_23_6_STM.pdf?src-supplier=Conrad; ComponentLink6Description: http://www.produktinfo.conrad.com/datenblaetter/1100000-1199999/001184109-da-01-en-IC_ESD_PROTECTI_USBLC6_2SC6_SOT_23_6_STM.pdf?src-supplier=Conrad; ComponentLink5URL: http://datasheet.iiic.cc/stmicroelectronics/USBLC6-2SC6.pdf?src-supplier=IIIC; ComponentLink5Description: http://datasheet.iiic.cc/stmicroelectronics/USBLC6-2SC6.pdf?src-supplier=IIIC; ComponentLink4URL: http://www.st.com/content/ccc/resource/technical/document/datasheet/06/1d/48/9c/6c/20/4a/b2/CD00050750.pdf/files/CD00050750.pdf/jcr:content/translations/en.CD00050750.pdf?src-supplier=Verical; ComponentLink4Description: http://www.st.com/content/ccc/resource/technical/document/datasheet/06/1d/48/9c/6c/20/4a/b2/CD00050750.pdf/files/CD00050750.pdf/jcr:content/translations/en.CD00050750.pdf?src-supplier=Verical; ComponentLink3URL: http://www.st.com/web/en/resource/technical/document/datasheet/CD00050750.pdf?src-supplier=Future+Electronics; ComponentLink3Description: http://www.st.com/web/en/resource/technical/document/datasheet/CD00050750.pdf?src-supplier=Future+Electronics; ComponentLink2URL: http://www.st.com/content/ccc/resource/technical/document/datasheet/06/1d/48/9c/6c/20/4a/b2/CD00050750.pdf/files/CD00050750.pdf/jcr:content/translations/en.CD00050750.pdf?src-supplier=Mouser; ComponentLink2Description: http://www.st.com/content/ccc/resource/technical/document/datasheet/06/1d/48/9c/6c/20/4a/b2/CD00050750.pdf/files/CD00050750.pdf/jcr:content/translations/en.CD00050750.pdf?src-supplier=Mouser; ComponentLink1URL: http://www.farnell.com/datasheets/1690354.pdf?src-supplier=Element14; ComponentLink1Description: http://www.farnell.com/datasheets/1690354.pdf?src-supplier=Element14; Component Kind: Standard; Clamping Voltage: 17V; Case/Package: SOT-23; Breakdown Voltage: 6V
TC1 = TC2030: Library Name: Tracker_v1_1_sch.SchLib; Component Kind: Standard
